# Altium schematic sheet I2C_Shield.SchDoc(I2C_Shield)
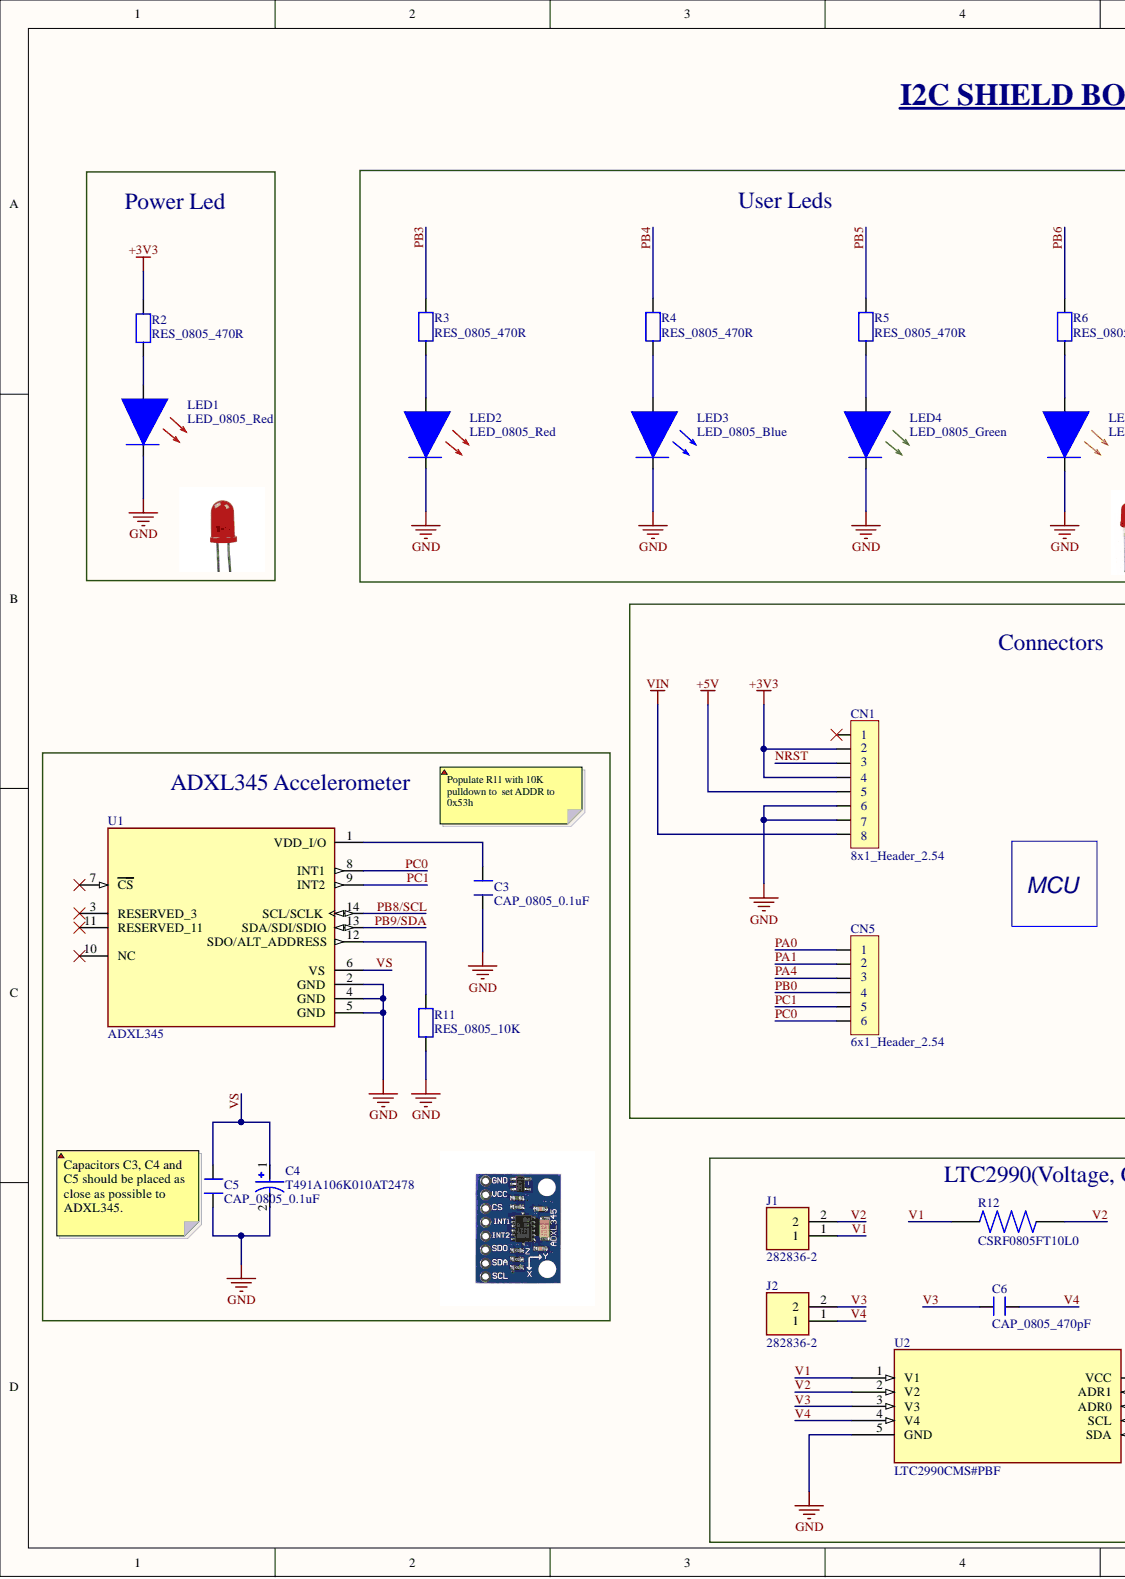
[diagram p0: I2C_Shield.SchDoc, left]
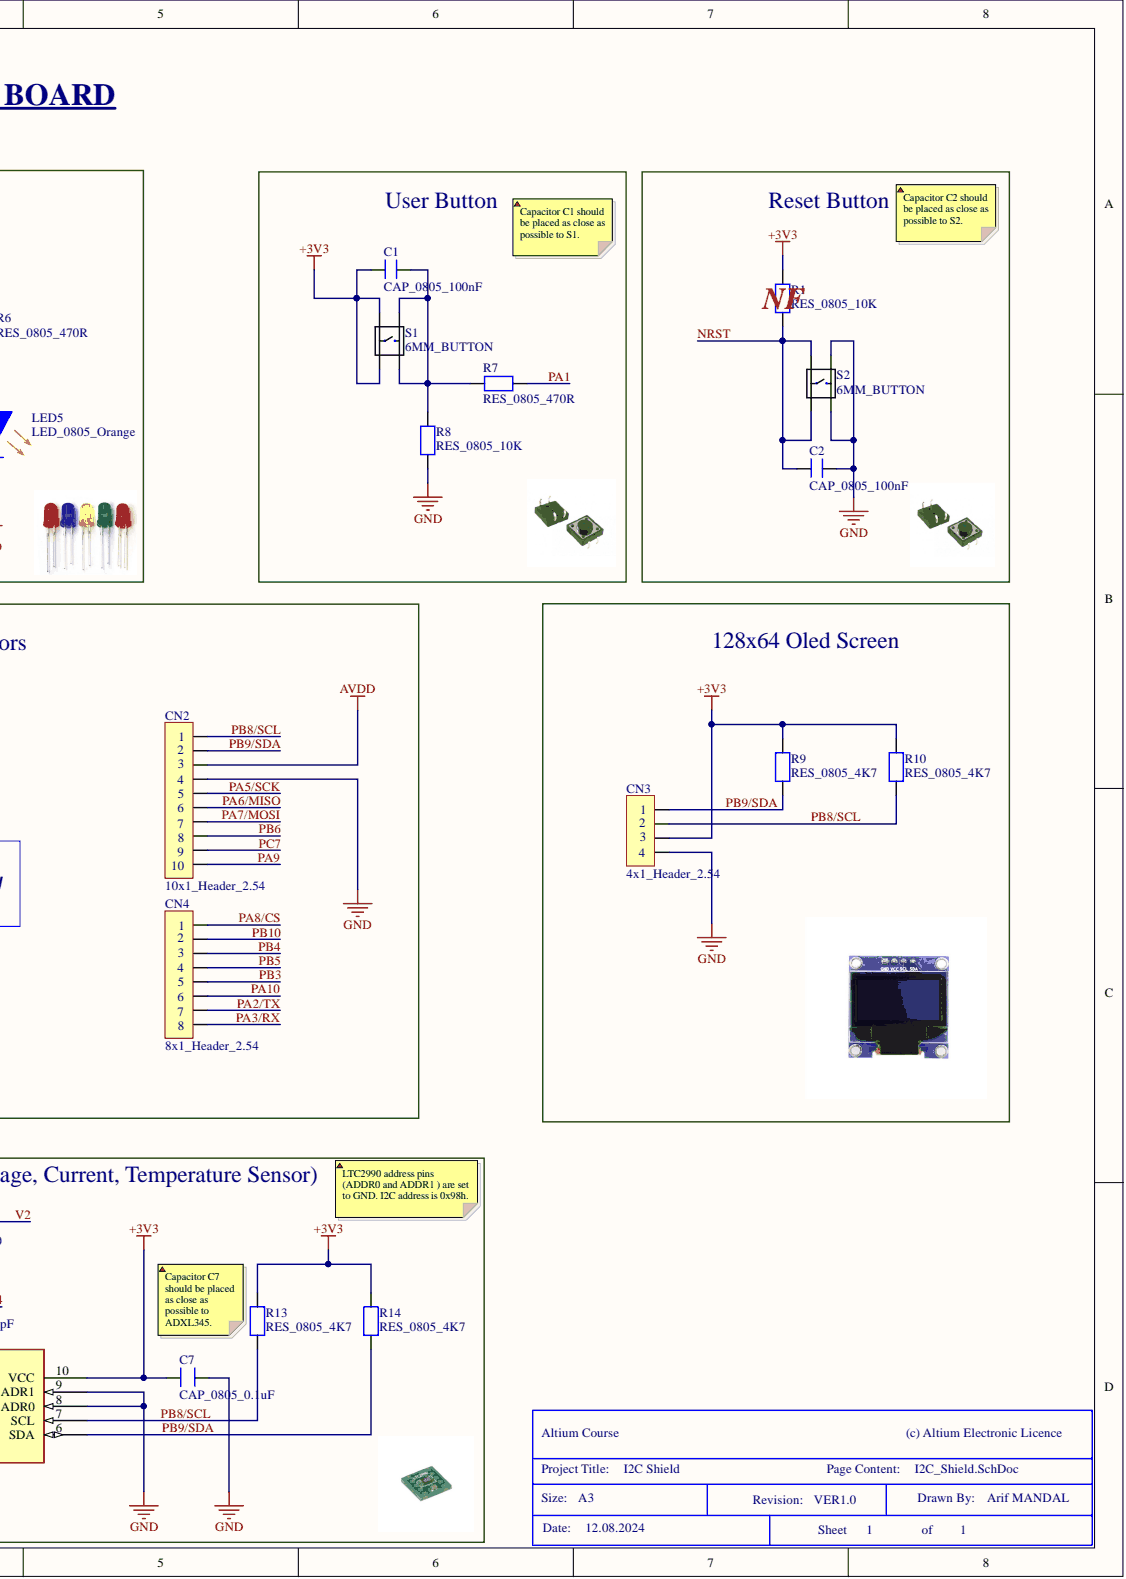
[diagram p1: I2C_Shield.SchDoc, right]

## Parts
C1  CAP_0805_100nF  [CAP_0805]
C2  CAP_0805_100nF  [CAP_0805]
C3  CAP_0805_0.1uF  [CAP_0805]
C4  T491A106K010AT2478  [CAPMP3216X180N]
C5  CAP_0805_0.1uF  [CAP_0805]
C6  CAP_0805_470pF  [CAP_0805]
C7  CAP_0805_0.1uF  [CAP_0805]
CN1  8x1_Header_2.54  [8x1_2.54MM_HEADER]
CN2  10x1_Header_2.54  [10x1_2.54MM_HEADER]
CN3  4x1_Header_2.54  [4x1_2.54MM_HEADER]
CN4  8x1_Header_2.54  [8x1_2.54MM_HEADER]
CN5  6x1_Header_2.54  [6x1_2.54MM_HEADER]
J1  282836-2  [TE_282836-2]
J2  282836-2  [TE_282836-2]
LED1  LED_0805_Red  [LED_0805]
LED2  LED_0805_Red  [LED_0805]
LED3  LED_0805_Blue  [LED_0805]
LED4  LED_0805_Green  [LED_0805]
LED5  LED_0805_Orange  [LED_0805]
R1  RES_0805_10K  [RES_0805]
R2  RES_0805_470R  [RES_0805]
R3  RES_0805_470R  [RES_0805]
R4  RES_0805_470R  [RES_0805]
R5  RES_0805_470R  [RES_0805]
R6  RES_0805_470R  [RES_0805]
R7  RES_0805_470R  [RES_0805]
R8  RES_0805_10K  [RES_0805]
R9  RES_0805_4K7  [RES_0805]
R10  RES_0805_4K7  [RES_0805]
R11  RES_0805_10K  [RES_0805]
R12  CSRF0805FT10L0  [0805_10M_SHUNT_RES]
R13  RES_0805_4K7  [RES_0805]
R14  RES_0805_4K7  [RES_0805]
S1  6MM_BUTTON  [6MM_TACTILE_SWITCH]
S2  6MM_BUTTON  [6MM_TACTILE_SWITCH]
U1  ADXL345  [PQFN80P500X300X100-14N]
U2  LTC2990CMS#PBF  [MSOP-10_MS]

## Nets
+3V3: C1.1 C7.2 CN1.02 CN1.04 CN3.03 R1.2 R2.2 R9.2 R10.2 R13.2 R14.2 S1.1 S1.3 U2.10(VCC)
+5V: CN1.05
AVDD: CN2.03
GND: C2.2 C3.1 C4.2 C5.1 C7.1 CN1.06 CN1.07 CN2.04 CN3.04 LED1.C LED2.C LED3.C LED4.C LED5.C R8.1 R11.1 S2.2 S2.4 U1.2(GND) U1.4(GND) U1.5(GND) U2.5(GND) U2.8(ADR0) U2.9(ADR1)
VIN: CN1.08
NRST: C2.1 CN1.03 R1.1 S2.1 S2.3
PA0: CN5.01
PA1: CN5.02 R7.2
PA2/TX: CN4.07
PA3/RX: CN4.08
PA4: CN5.03
PA5/SCK: CN2.05
PA6/MISO: CN2.06
PA7/MOSI: CN2.07
PA8/CS: CN4.01
PA9: CN2.10
PA10: CN4.06
PB0: CN5.04
PB3: CN4.05 R3.2
PB4: CN4.03 R4.2
PB5: CN4.04 R5.2
PB6: CN2.08 R6.2
PB8/SCL: CN2.01 CN3.02 R10.1 R13.1 U1.14(SCL/SCLK) U2.7(SCL)
PB9/SDA: CN2.02 CN3.01 R9.1 R14.1 U1.13(SDA/SDI/SDIO) U2.6(SDA)
PB10: CN4.02
PC0: CN5.06 U1.8(INT1)
PC1: CN5.05 U1.9(INT2)
PC7: CN2.09
V1: J1.1 R12.1 U2.1(V1)
V2: J1.2 R12.2 U2.2(V2)
V3: C6.1 J2.2 U2.3(V3)
V4: C6.2 J2.1 U2.4(V4)
VS: C4.1 C5.2 U1.6(VS)
NetC1_2: C1.2 R7.1 R8.2 S1.2 S1.4
NetC3_2: C3.2 U1.1(VDD_I/O)
NetLED1_A: LED1.A R2.1
NetLED2_A: LED2.A R3.1
NetLED3_A: LED3.A R4.1
NetLED4_A: LED4.A R5.1
NetLED5_A: LED5.A R6.1
NetR11_2: R11.2 U1.12(SDO/ALT_ADDRESS)

Not connected: CN1 01; U1 3(RESERVED_3) 7(CS) 10(NC) 11(RESERVED_11)

## Library data of the parts
C1 C2 = CAP_0805_100nF: 0805 100nF 16VDC ±10% X7R; Voltage Rating: 16V; Tolerance: %10; Package Size: 0805; Manufacturer: https://ozdisan.com/pasif-komponentler/kapasitorler/smt-smd-ve-mlcc-kapasitorler/CC0805KRX7R7BB104/748339; Library Name: I2C_Shield.SchLib; Dielectric: X7R; Component Kind: Standard
C3 C5 C7 = CAP_0805_0.1uF: 0805 0.1uF 16VDC ±10% X7R; Voltage Rating: 16V; Tolerance: %10; Package Size: 0805; Manufacturer: https://ozdisan.com/pasif-komponentler/kapasitorler/smt-smd-ve-mlcc-kapasitorler/CC0805KRX7R7BB104/748339; Library Name: I2C_Shield.SchLib; Dielectric: X7R; Component Kind: Standard
C4 = T491A106K010AT2478: 10 ÂµF Molded Tantalum Capacitors 10 V 1206 (3216 Metric) 3.8Ohm; MF: KEMET; SnapEDA_Link: https://www.snapeda.com/parts/T491A106K010AT2478/KEMET/view-part/?ref=snap; Purchase-URL: https://pricing.snapeda.com/search/part/T491A106K010AT2478/?ref=eda; Price: None; Package: SMD-2 KEMET; MP: T491A106K010AT2478; Library Name: tantalum10uF.IntLib; Component Kind: Standard; Check_prices: https://www.snapeda.com/parts/T491A106K010AT2478/KEMET/view-part/?ref=eda; Availability: In Stock
C6 = CAP_0805_470pF: 0805 470pF 16VDC ±10% X7R; Voltage Rating: 16V; Tolerance: %10; Package Size: 0805; Manufacturer: https://ozdisan.com/pasif-komponentler/kapasitorler/smt-smd-ve-mlcc-kapasitorler/CC0805KRX7R7BB104/748339; Library Name: I2C_Shield.SchLib; Dielectric: X7R; Component Kind: Standard
CN1 CN4 = 8x1_Header_2.54: Arduino Boards Connector; Package Size: 8x1; Manufacturer: https://www.e-komponent.com/arduino-boards-or-other-mcu-boards-connector?gad_source=4&gclid=CjwKCAjw74e1BhBnEiwAbqOAjEA8jWhKcu_OL1z7lHxmv6-QK3mXRxhYjeYuodVaUuIY0-tXQ3XZXRoCzkoQAvD_BwE; Library Name: I2C_Shield.SchLib; Component Kind: Standard
CN2 = 10x1_Header_2.54: Arduino Boards Connector; Package Size: 10x1; Manufacturer: https://www.e-komponent.com/arduino-boards-or-other-mcu-boards-connector?gad_source=4&gclid=CjwKCAjw74e1BhBnEiwAbqOAjEA8jWhKcu_OL1z7lHxmv6-QK3mXRxhYjeYuodVaUuIY0-tXQ3XZXRoCzkoQAvD_BwE; Library Name: I2C_Shield.SchLib; Component Kind: Standard
CN3 = 4x1_Header_2.54: Oled Screen Header; Package Size: 4x1; Manufacturer: https://ozdisan.com/konnektor-ve-baglanti-elemanlari/header-konnektorler/disi-headerlar/DS1023-1X4S21/353863; Library Name: I2C_Shield.SchLib; Component Kind: Standard
CN5 = 6x1_Header_2.54: Arduino Boards Connector; Package Size: 6x1; Manufacturer: https://www.e-komponent.com/arduino-boards-or-other-mcu-boards-connector?gad_source=4&gclid=CjwKCAjw74e1BhBnEiwAbqOAjEA8jWhKcu_OL1z7lHxmv6-QK3mXRxhYjeYuodVaUuIY0-tXQ3XZXRoCzkoQAvD_BwE; Library Name: I2C_Shield.SchLib; Component Kind: Standard
J1 J2 = 282836-2: Connector, Terminal Block; Wire Receptacle; 2 Pos.; 16-30 AWG; 0.197 in. CL; Sid; MF: TE Connectivity; SnapEDA_Link: https://www.snapeda.com/parts/282836-2/TE+Connectivity+AMP+Connectors/view-part/?ref=snap; Purchase-URL: https://pricing.snapeda.com/search/part/282836-2/?ref=eda; Price: None; Package: None; MP: 282836-2; Component Kind: Standard; Check_prices: https://www.snapeda.com/parts/282836-2/TE+Connectivity+AMP+Connectors/view-part/?ref=eda; Availability: In Stock; Library Name: terminalblock-2.IntLib
LED1 LED2 = LED_0805_Red: LED SMD 0805 RED 550mcd; Voltage Rating: 2.6; Current: 20mA; Package Size: 0805; Manufacturer: https://ozdisan.com/led-ve-aydinlatma-cozumleri/led-komponentler/sinyal-ledler-tek-renkli/HL-PSC-2012U51GC/471651; Library Name: I2C_Shield.SchLib; Component Kind: Standard
LED3 = LED_0805_Blue: LED SMD 0805 BLUE 550mcd; Voltage Rating: 2.6; Current: 20mA; Package Size: 0805; Manufacturer: https://ozdisan.com/led-ve-aydinlatma-cozumleri/led-komponentler/sinyal-ledler-tek-renkli/HL-PSC-2012U51GC/471651; Library Name: I2C_Shield.SchLib; Component Kind: Standard
LED4 = LED_0805_Green: LED SMD 0805 GREEN 550mcd; Voltage Rating: 2.6; Current: 20mA; Package Size: 0805; Manufacturer: https://ozdisan.com/led-ve-aydinlatma-cozumleri/led-komponentler/sinyal-ledler-tek-renkli/HL-PSC-2012U51GC/471651; Library Name: I2C_Shield.SchLib; Component Kind: Standard
LED5 = LED_0805_Orange: LED SMD 0805 ORANGE 550mcd; Voltage Rating: 2.6; Current: 20mA; Package Size: 0805; Manufacturer: https://ozdisan.com/led-ve-aydinlatma-cozumleri/led-komponentler/sinyal-ledler-tek-renkli/HL-PSC-2012U51GC/471651; Library Name: I2C_Shield.SchLib; Component Kind: Standard
R1 R8 R11 = RES_0805_10K: 0805 10K Ohms 5% 1/8W; Voltage Rating: 150V; Tolerance: %5; Package Size: 0805; Manufacturer: https://ozdisan.com/pasif-komponentler/direncler/smt-smd-ve-cip-direncler/RC0805JR-0710KL/515420; Library Name: I2C_Shield.SchLib; Component Kind: Standard
R2-R7 = RES_0805_470R: 0805 470 Ohms 5% 1/8W; Voltage Rating: 150V; Tolerance: %5; Package Size: 0805; Manufacturer: https://ozdisan.com/pasif-komponentler/direncler/smt-smd-ve-cip-direncler/RC0805JR-07470RL/504397; Library Name: I2C_Shield.SchLib; Component Kind: Standard
R9 R10 R13 R14 = RES_0805_4K7: 0805 4K7 Ohms 5% 1/8W; Voltage Rating: 150V; Tolerance: %5; Package Size: 0805; Manufacturer: https://ozdisan.com/pasif-komponentler/direncler/smt-smd-ve-cip-direncler/RC0805JR-0710KL/515420; Library Name: I2C_Shield.SchLib; Component Kind: Standard
S1 S2 = 6MM_BUTTON: SWITCH TACT 6x6MM; Voltage Rating: 12V; Current: 50mA; Package Size: 6mmx6mm; Manufacturer: https://ozdisan.com/elektromekanik-komponentler/sivicler-ve-anahtarlar/tact-sivicler/DS1042-03-116-KKR-250-10/586990; Library Name: I2C_Shield.SchLib; Component Kind: Standard
U1 = ADXL345: Accelerometer X, Y, Z Axis ±2g, 4g, 8g, 16g 0.05Hz ~ 1.6kHz 14-LGA (3x5); MF: Analog Devices, Inc.; SnapEDA_Link: https://www.snapeda.com/parts/ADXL345/Analog+Devices/view-part/?ref=snap; Package: LGA -14 Analog Devices; MP: ADXL345; Library Name: ADXL345.IntLib; Component Kind: Standard; Check_prices: https://www.snapeda.com/parts/ADXL345/Analog+Devices/view-part/?ref=eda
U2 = LTC2990CMS#PBF: Quad I2C Voltage, Current and Temperature Monitor; MF: Analog Devices; SnapEDA_Link: https://www.snapeda.com/parts/LTC2990CMS%23PBF/Analog+Devices/view-part/?ref=snap; Purchase-URL: https://pricing.snapeda.com/search/part/LTC2990CMS#PBF/?ref=eda; Price: None; Package: MSOP-10 Analog Devices; MP: LTC2990CMS#PBF; Library Name: LTC2990CMS_PBF.IntLib; Component Kind: Standard; Check_prices: https://www.snapeda.com/parts/LTC2990CMS%23PBF/Analog+Devices/view-part/?ref=eda; Availability: In Stock
R12 = CSRF0805FT10L0: Price: None; Package: None; MP: CSRF0805FT10L0; Library Name: CSRF0805FT10L0.IntLib; Component Kind: Standard; Availability: Unavailable
